# Altium schematic sheet IPM_Brake.SchDoc
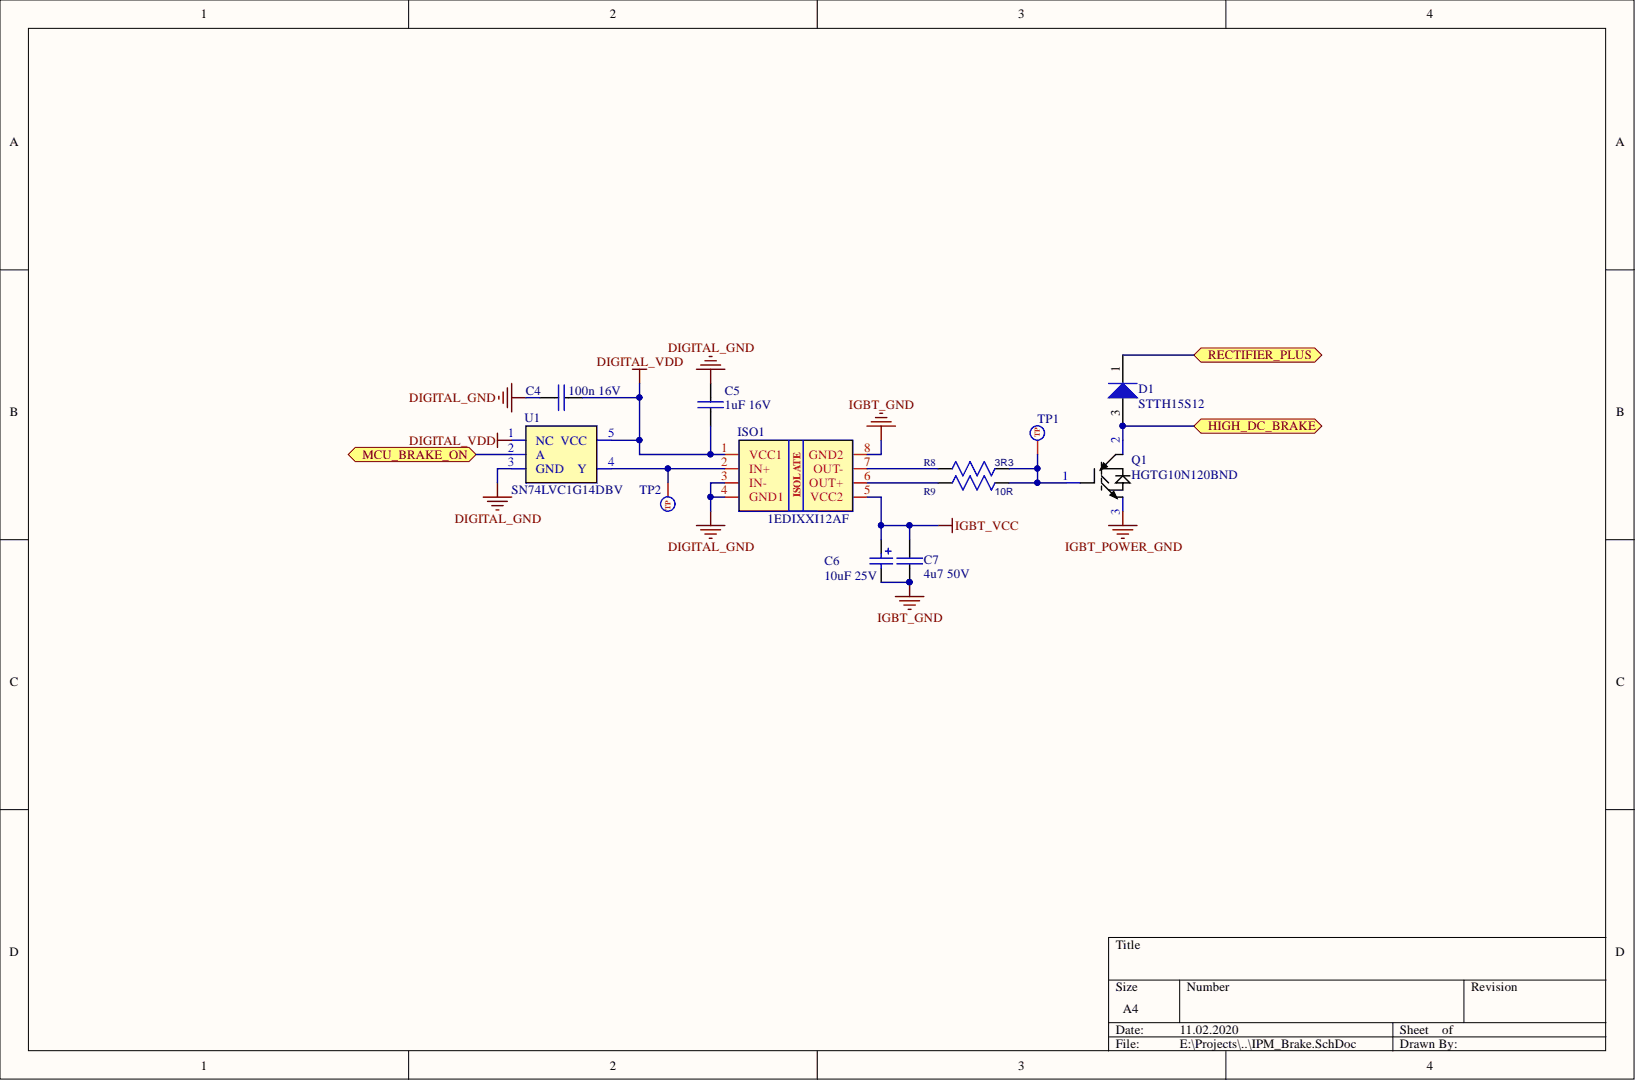
[diagram p0: IPM_Brake.SchDoc]

## Parts
C4  100n 16V  [C_0805]
C5  1uF 16V  [C_0805]
C6  10uF 25V  [C]
C7  4u7 50V  [C_0805]
D1  STTH15S12  [TO220-AC_BOTTOM_HORIZONTAL]
ISO1  1EDIXXI12AF  [PG-DSO-8-51]
Q1  HGTG10N120BND  [TO-247AD_BOTTOM_HORIZONTAL]
R8  3R3  [R_1206]
R9  10R  [R_1206]
TP1  TEST_POINT  [TEST POINT D1.5]  (value not drawn)
TP2  TEST_POINT  [TEST POINT D1.5]  (value not drawn)
U1  SN74LVC1G14DBV  [SOT23-5]

## Ports
HIGH_DC_BRAKE, MCU_BRAKE_ON, RECTIFIER_PLUS

## Nets
DIGITAL_GND: C4.1 C5.2 ISO1.3(IN-) ISO1.4(GND1) U1.3(GND)
DIGITAL_VDD: C4.2 C5.1 ISO1.1(VCC1) U1.1(NC) U1.5(VCC)
IGBT_GND: C6.2 C7.1 ISO1.8(GND2)
IGBT_POWER_GND: Q1.3
IGBT_VCC: C6.1 C7.2 ISO1.5(VCC2)
HIGH_DC_BRAKE: D1.3 Q1.2 ; port HIGH_DC_BRAKE
MCU_BRAKE_ON: U1.2(A) ; port MCU_BRAKE_ON
RECTIFIER_PLUS: D1.1 ; port RECTIFIER_PLUS
NetISO1_2: ISO1.2(IN+) TP2.1 U1.4(Y)
NetISO1_6: ISO1.6(OUT+) R9.2
NetISO1_7: ISO1.7(OUT-) R8.2
NetQ1_1: Q1.1 R8.1 R9.1 TP1.1

## Library data of the parts
C4 C5 C7 = Cap NP: CERAMIC CAPACITOR; Component Kind: Standard; Library Name: Capacitors.SchLib
  C4: Value: 100n 16V
  C5: Value: 1uF 16V
  C7: Value: 4u7 50V
C6 = T491C: Solid Tantalum Chip Capacitor, Standard T491 Series - Industrial Grade; Code_JEITA: 6032-28; Component Kind: Standard; ComponentLink1Description: Manufacturer Link; ComponentLink1URL: http://www.kemet.com/; ComponentLink2Description: Datasheet; ComponentLink2URL: http://www.kemet.com/kemet/web/homepage/kechome.nsf/vapubfilesname/F3102TA.pdf/$file/F3102TA.pdf; DatasheetDocument: Mar-2001; LatestRevisionDate: 19-Mar-2004; LatestRevisionNote: Silkscreen dimensions re-sized.; Library Name: Capacitors.SchLib; PackageDocument: Mar-2001; PackageReference: C; Published: 17-Jul-2002; Signal Integrity: T491C; Simulation: CAP; Value: 10uF 25V; Publisher: Altium Limited
D1 = STTH15S12: Ultrafast recovery - high voltage diode; Component Kind: Standard; Library Name: Diode.SchLib; Value: STTH15S12
ISO1 = 1EDIXXI12AF: Single Channel IGBT Gate Driver IC; Component Kind: Standard; Library Name: GateDriver.SchLib; Value: 1EDIXXI12AF
Q1 = HGTG10N120BND: 35A, 1200V, NPT Series N-Channel IGBT   with Anti-Parallel Hyperfast Diode; Component Kind: Standard; Library Name: Transistors.SchLib; Value: HGTG10N120BND
R8 R9 = R1206: Resistor; Component Kind: Standard; Library Name: Resistors.SchLib
  R8: Value: 3R3
  R9: Value: 10R
TP1 TP2 = TEST_POINT: Signal Test Point; Component Kind: Standard; Library Name: Discrete.SchLib
U1 = SN74LVC1G14DBV: Syngle Inverter Schmitt Gate SOT23-5; Component Kind: Standard; Value: SN74LVC1G14DBV; Library Name: Gates.SchLib
